# Altium schematic sheet pmod_microphone.SchDoc
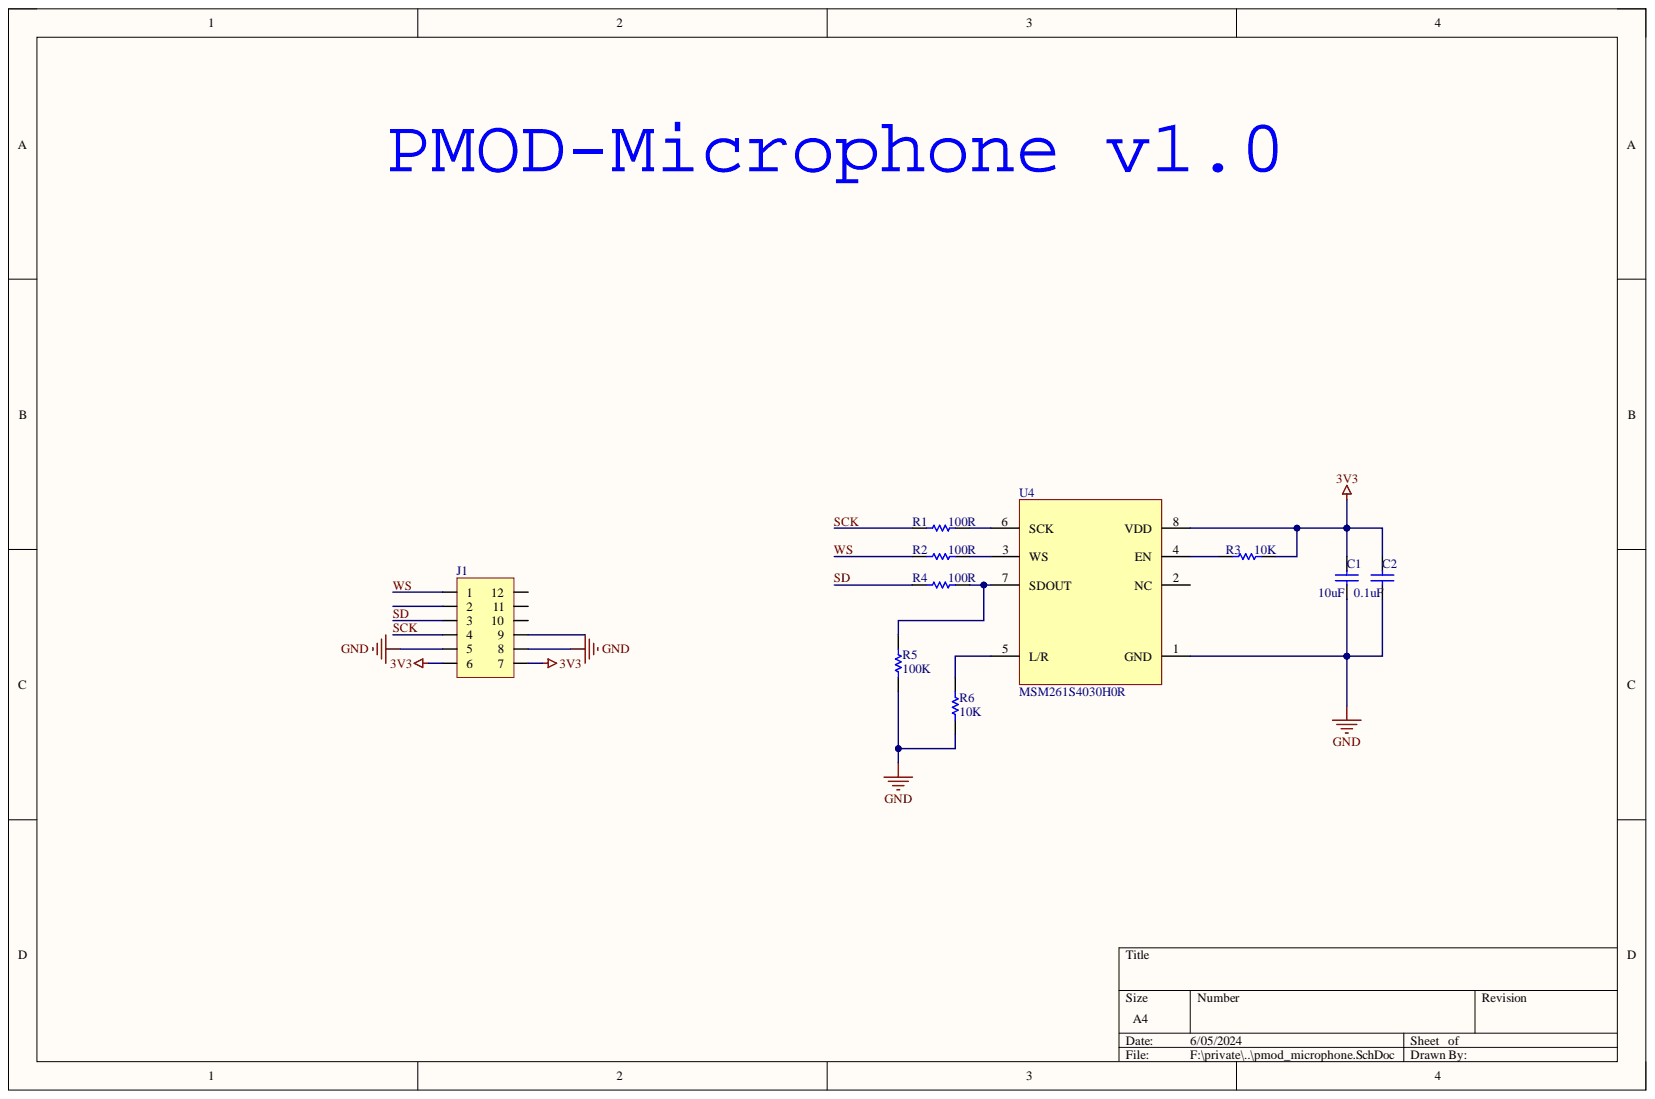
[diagram p0: pmod_microphone.SchDoc]

## Parts
C1  10uF  [0603_C]
C2  0.1uF  [0603_C]
J1  (no value)  [HEADER_2x6]
R1  100R  [0603_R]
R2  100R  [0603_R]
R3  10K  [0603_R]
R4  100R  [0603_R]
R5  100K  [0603_R]
R6  10K  [0603_R]
U4  MSM261S4030H0R  [MIC_QFN8]

## Nets
3V3: C1.2 C2.2 J1.6 J1.7 R3.1 U4.8(VDD)
GND: C1.1 C2.1 J1.5 J1.8 R5.1 R6.2 U4.1(GND)
SCK: J1.4 R1.2
SD: J1.3 R4.2
WS: J1.1 R2.2
NetR1_1: R1.1 U4.6(SCK)
NetR2_1: R2.1 U4.3(WS)
NetR3_2: R3.2 U4.4(EN)
NetR4_1: R4.1 R5.2 U4.7(SDOUT)
NetR6_1: R6.1 U4.5(L/R)

Not connected: J1 2 9 10 11 12; U4 2(NC)

## Library data of the parts
C1 C2 = CAP: Capacitor; value: 1uF; Component Kind: Standard; Library Name: Cheetie Integrated Library 2017.IntLib
J1 = Header 2x6: Header, 4-Pin; Component Kind: Standard; LatestRevisionDate: 17-Jul-2002; LatestRevisionNote: Re-released for DXP Platform.; Signal Integrity: Connector; Library Name: pmod_vga.SCHLIB; Publisher: Altium Limited
R1-R6 = R0603: Resistor; Component Kind: Standard; LatestRevisionDate: 17-Jul-2002; LatestRevisionNote: Re-released for DXP Platform.; Library Name: MYLIB.IntLib; PackageDescription: Axial Device, Thru-Hole; 2 Leads; 0.3 in Pin Spacing; PackageReference: AXIAL-0.3; Published: 8-Jun-2000; Signal Integrity: Res; Simulation: RESISTOR; Publisher: Altium Limited
  R1 R2 R4: Value: 100R
  R3 R6: Value: 10K
  R5: Value: 100K
U4 = MSM261S4030H0R: Component Kind: Standard; Library Name: nanoESP32S3-ExtBoard.SCHLIB
